# Altium schematic sheet CC2530传感器功能底板.SchDoc(CC2530传感器功能底板)
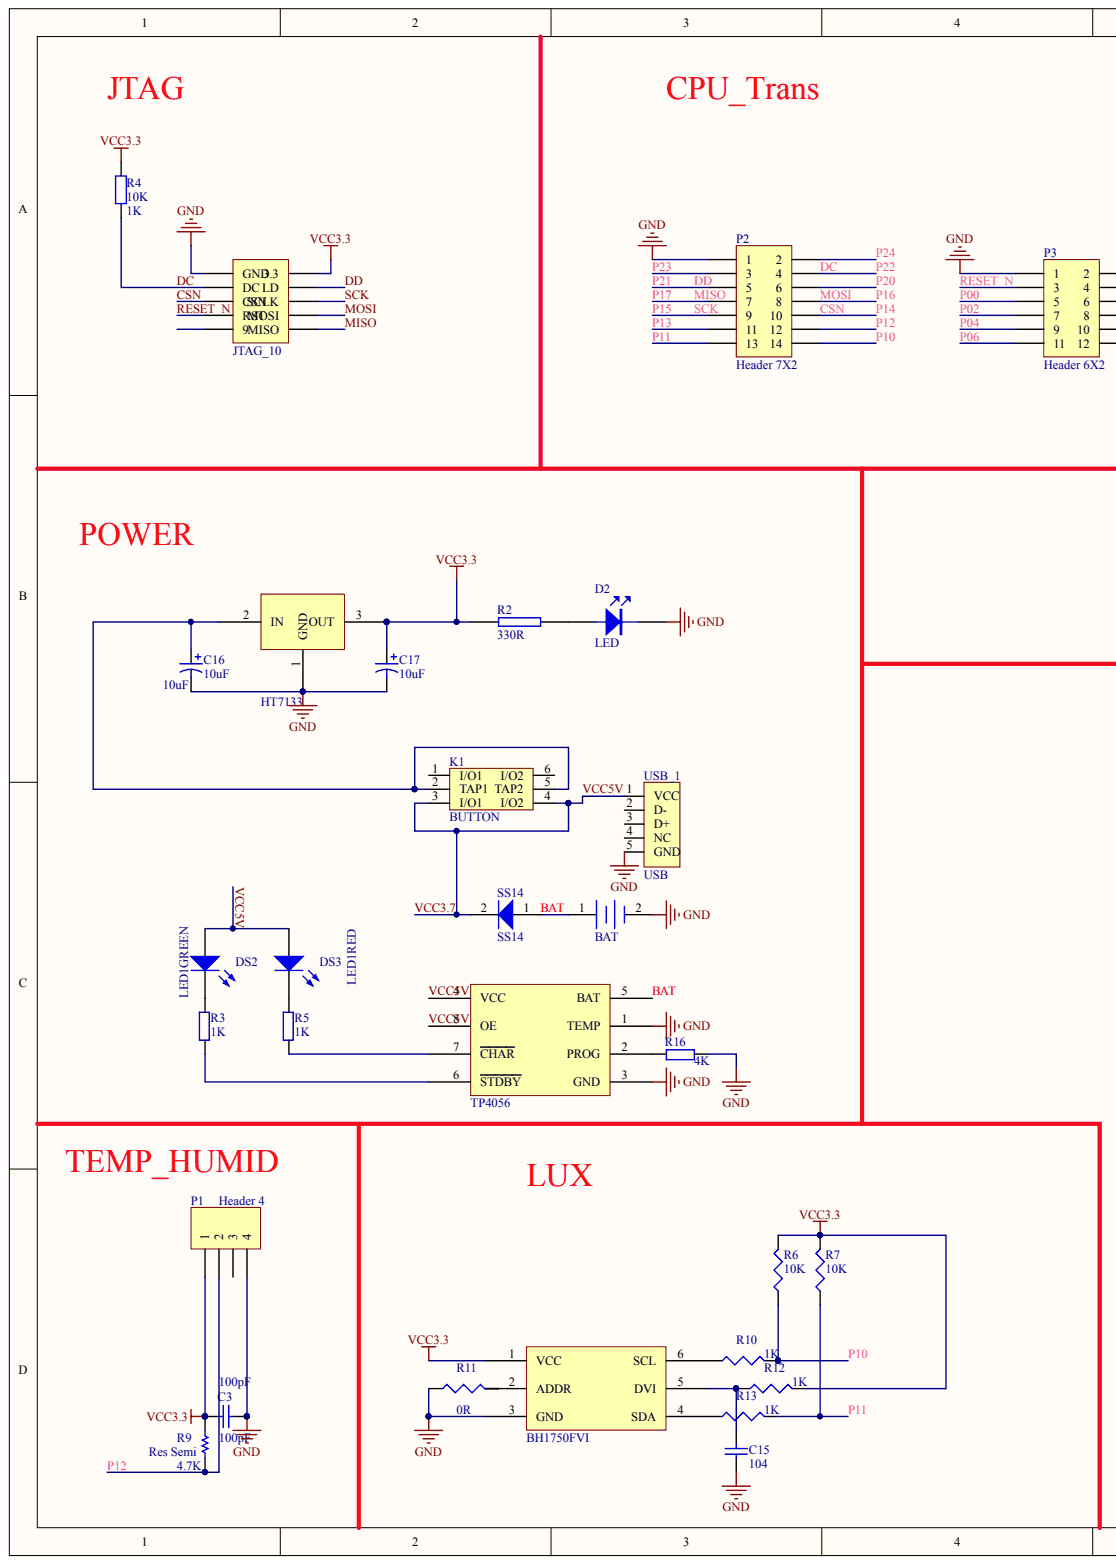
[diagram p0: CC2530传感器功能底板.SchDoc, left]
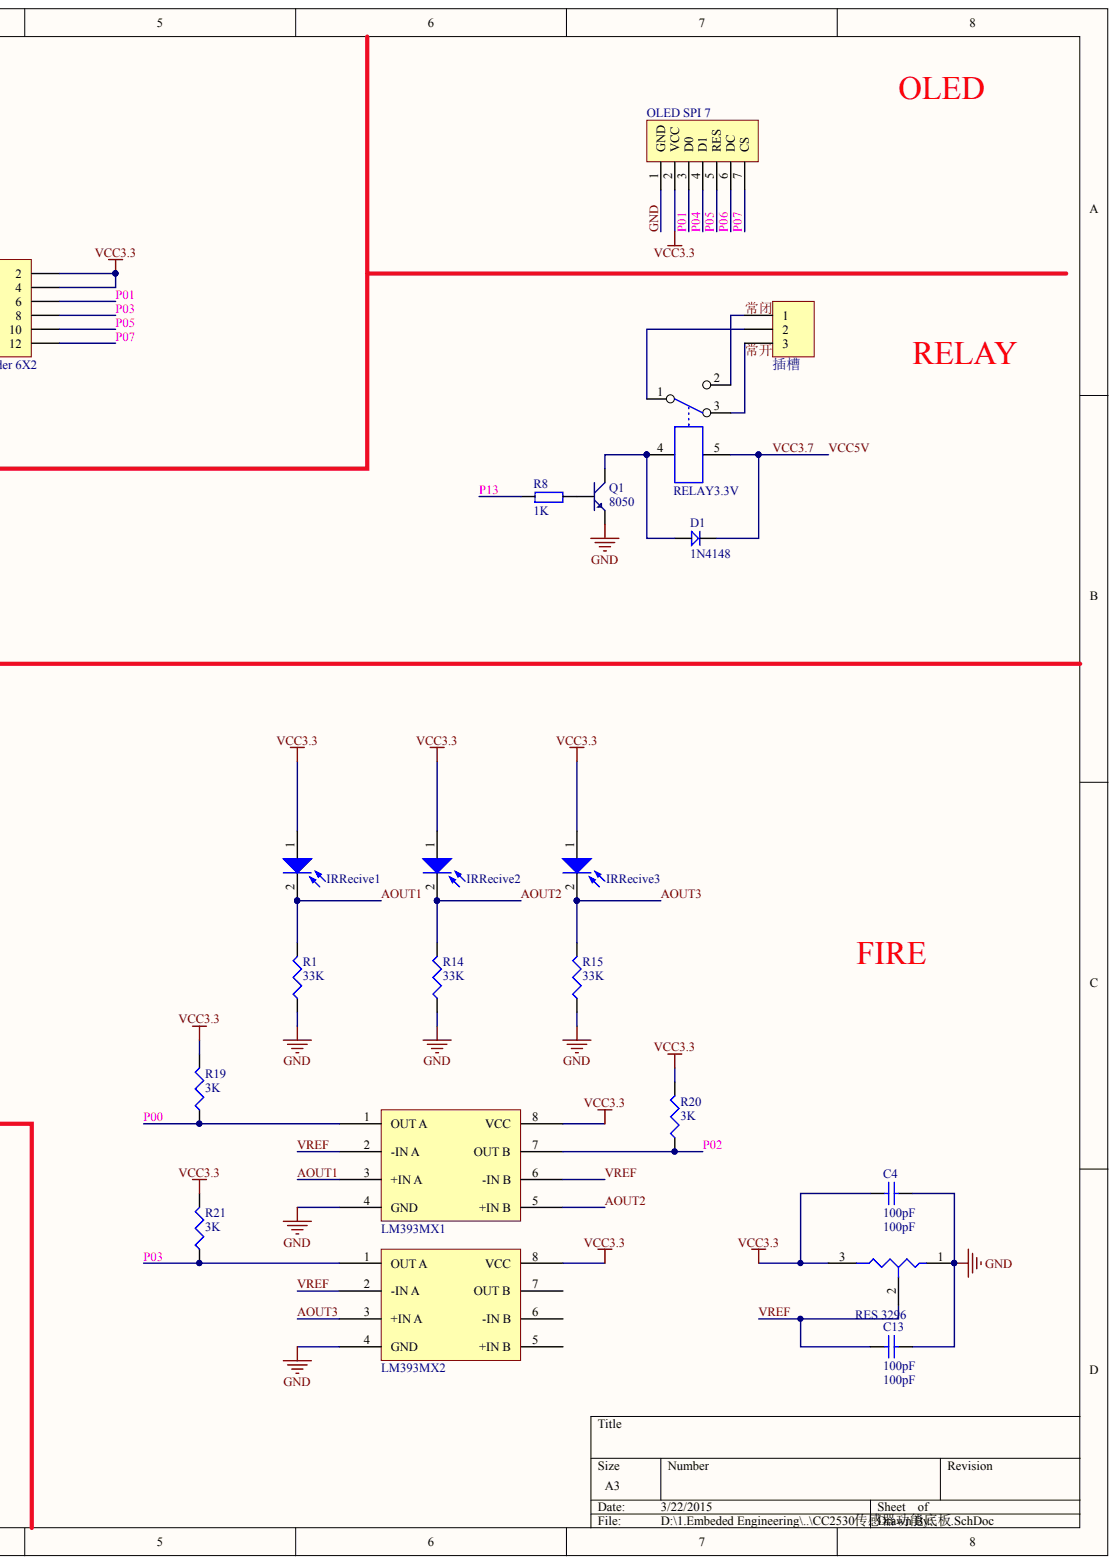
[diagram p1: CC2530传感器功能底板.SchDoc, right]

## Parts
BAT  BAT
BH1750FVI  BH1750FVI
C3  100pF
C4  100pF
C13  100pF
C15  104
C16  10uF
C17  10uF
D1  1N4148
D2  LED
DS2  LED1GREEN
DS3  LED1RED
HT7133  HT7133
IRRecive1  IRRecive
IRRecive2  IRRecive
IRRecive3  IRRecive
JTAG_10  JTAG_10
K1  BUTTON
LM393MX1  LM393MX
LM393MX2  LM393MX
OLED_SPI_7  OLED SPI 7
P1  Header 4
P2  Header 7X2
P3  Header 6X2
Q1  8050
R1  value 33K  (hidden comment: Res3)
R2  330R
R3  1K
R4  10K  value 1K
R5  1K
R6  value 10K  (hidden comment: Res3)
R7  value 10K  (hidden comment: Res3)
R8  1K
R9  Res Semi  value 4.7K
R10  value 1K  (hidden comment: Res3)
R11  value 0R  (hidden comment: Res3)
R12  value 1K  (hidden comment: Res3)
R13  value 1K  (hidden comment: Res3)
R14  value 33K  (hidden comment: Res3)
R15  value 33K  (hidden comment: Res3)
R16  4K
R19  value 3K  (hidden comment: Res3)
R20  value 3K  (hidden comment: Res3)
R21  value 3K  (hidden comment: Res3)
RELAY3.3V  RELAY3.3V
RES_3296  RES 3296
SS14  SS14
TP4056  TP4056
USB_1  USB
插槽  插槽

## Nets
VCC3.3: BH1750FVI.1(VCC) C3.1 C4.1 C17.1 HT7133.3 IRRecive1.1 IRRecive2.1 IRRecive3.1 JTAG_10.2(3.3) LM393MX1.8(VCC) LM393MX2.8(VCC) OLED_SPI_7.2(VCC) P1.1 P3.2 P3.4 R2.2 R4.2 R6.2 R7.2 R9.2 R12.2 R19.2 R20.2 R21.2 RES_3296.3
AOUT1: IRRecive1.2 LM393MX1.3(+IN_A) R1.2
AOUT2: IRRecive2.2 LM393MX1.5(+IN_B) R14.2
AOUT3: IRRecive3.2 LM393MX2.3(+IN_A) R15.2
BAT: BAT.1 SS14.1 TP4056.5(BAT)
CSN: JTAG_10.5(CSN) P2.10
DC: JTAG_10.3(DC) P2.4 R4.1
DD: JTAG_10.4(LD) P2.5
GND: BAT.2 BH1750FVI.3(GND) C3.2 C4.2 C13.2 C15.1 C16.2 C17.2 D2.K HT7133.1 JTAG_10.1(GND) LM393MX1.4(GND) LM393MX2.4(GND) OLED_SPI_7.1(GND) P1.4 P2.1 P3.1 Q1.1 R1.1 R11.1 R14.1 R15.1 R16.2 RES_3296.1 TP4056.1(TEMP) TP4056.3(GND) USB_1.5(GND)
MISO: JTAG_10.10(9MISO) P2.7
MOSI: JTAG_10.8(MOSI) P2.8
P00: LM393MX1.1(OUT_A) P3.5 R19.1
P01: OLED_SPI_7.3(D0) P3.6
P02: LM393MX1.7(OUT_B) P3.7 R20.1
P03: LM393MX2.1(OUT_A) P3.8 R21.1
P04: OLED_SPI_7.4(D1) P3.9
P05: OLED_SPI_7.5(RES) P3.10
P06: OLED_SPI_7.6(DC) P3.11
P07: OLED_SPI_7.7(CS) P3.12
P10: P2.14 R6.1 R10.2
P11: P2.13 R7.1 R13.2
P12: P1.2 P2.12 R9.1
P13: P2.11 R8.1
P15: JTAG_10.6(SCLK) P2.9
P20: P2.6
P23: P2.3
P24: P2.2
RESET_N: JTAG_10.7(RST) P3.3
VCC3.7: D1.2 DS2.1 DS3.1 K1.3(I/O1) K1.4(I/O2) RELAY3.3V.5 SS14.2 TP4056.4(VCC) TP4056.8(OE) USB_1.1(VCC)
VREF: C13.1 LM393MX1.2(-IN_A) LM393MX1.6(-IN_B) LM393MX2.2(-IN_A) RES_3296.2
常开: RELAY3.3V.3 插槽.3
常闭: RELAY3.3V.2 插槽.1
NetBH1750FVI_2: BH1750FVI.2(ADDR) R11.2
NetBH1750FVI_4: BH1750FVI.4(SDA) R13.1
NetBH1750FVI_5: BH1750FVI.5(DVI) C15.2 R12.1
NetBH1750FVI_6: BH1750FVI.6(SCL) R10.1
NetC16_1: C16.1 HT7133.2 K1.2(TAP1) K1.5(TAP2)
NetD1_1: D1.1 Q1.3 RELAY3.3V.4
NetD2_A: D2.A R2.1
NetDS2_2: DS2.2 R3.1
NetDS3_2: DS3.2 R5.1
NetQ1_2: Q1.2 R8.2
NetR3_2: R3.2 TP4056.6(STDBY)
NetR5_2: R5.2 TP4056.7(CHAR)
NetR16_1: R16.1 TP4056.2(PROG)
NetRELAY3.3V_1: RELAY3.3V.1 插槽.2

Not connected: JTAG_10 9; K1 1(I/O1) 6(I/O2); LM393MX2 5(+IN_B) 6(-IN_B) 7(OUT_B); P1 3; USB_1 2(D-) 3(D+) 4(NC)

## Library data of the parts
BAT BH1750FVI C3 C4 C13 C15-C17 D1 D2 DS2 DS3 HT7133 IRRecive1-IRRecive3 JTAG_10 K1 LM393MX1 LM393MX2 OLED_SPI_7 P1-P3 Q1 R1-R16 R19-R21 RELAY3.3V RES_3296 SS14 TP4056 USB_1 插槽 = (no symbol name)
  C3: Published: 8-Jun-2000; LatestRevisionDate: 17-Jul-2002; LatestRevisionNote: Re-released for DXP Platform.; PackageReference: RAD-0.3; PackageDescription: Radial Cap, Thru-Hole; 2 Leads; 0.3 in Pin Spacing; Value: 100pF
  C4 C13: Published: 8-Jun-2000; LatestRevisionDate: 29-May-2009; LatestRevisionNote: IPC-7351 Footprint Added.; PackageReference: C1206; PackageDescription: Chip Capacitor; Value: 100pF
  C15: Published: 8-Jun-2000; LatestRevisionDate: 29-May-2009; LatestRevisionNote: IPC-7351 Footprint Added.; PackageReference: C1206; PackageDescription: Chip Capacitor; Value: 104
  C16 C17: Published: 8-Jun-2000; LatestRevisionDate: 17-Jul-2002; LatestRevisionNote: Re-released for DXP Platform.; PackageReference: RB7.6-15; PackageDescription: Polarized Capacitor (Radial); 2 Leads; Value: 10uF
  DS2 DS3: Published: 8-Jun-2000; LatestRevisionDate: 17-Jul-2002; LatestRevisionNote: Re-released for DXP Platform.; PackageDocument: Feb-2002; PackageReference: 3.2X1.6X1.1
  P1-P3: LatestRevisionDate: 17-Jul-2002; LatestRevisionNote: Re-released for DXP Platform.
  R1 R6 R7 R10-R15 R19-R21: Published: 8-Jun-2000; LatestRevisionDate: 29-May-2009; LatestRevisionNote: IPC-7351 Footprint Added.; PackageReference: J1-0603; PackageDescription: Chip Resistor
  R9: Published: 8-Jun-2000; LatestRevisionDate: 17-Jul-2002; LatestRevisionNote: Re-released for DXP Platform.; PackageReference: AXIAL-0.5; PackageDescription: Axial Device, Thru-Hole; 2 Leads; 0.5 in Pin Spacing
  SS14: : *
  C3 C4 C13 C15-C17 DS2 DS3 P1-P3 R1 R6 R7 R9-R15 R19-R21: Publisher: Altium Limited
